# Altium schematic sheet SolarTracker.SchDoc
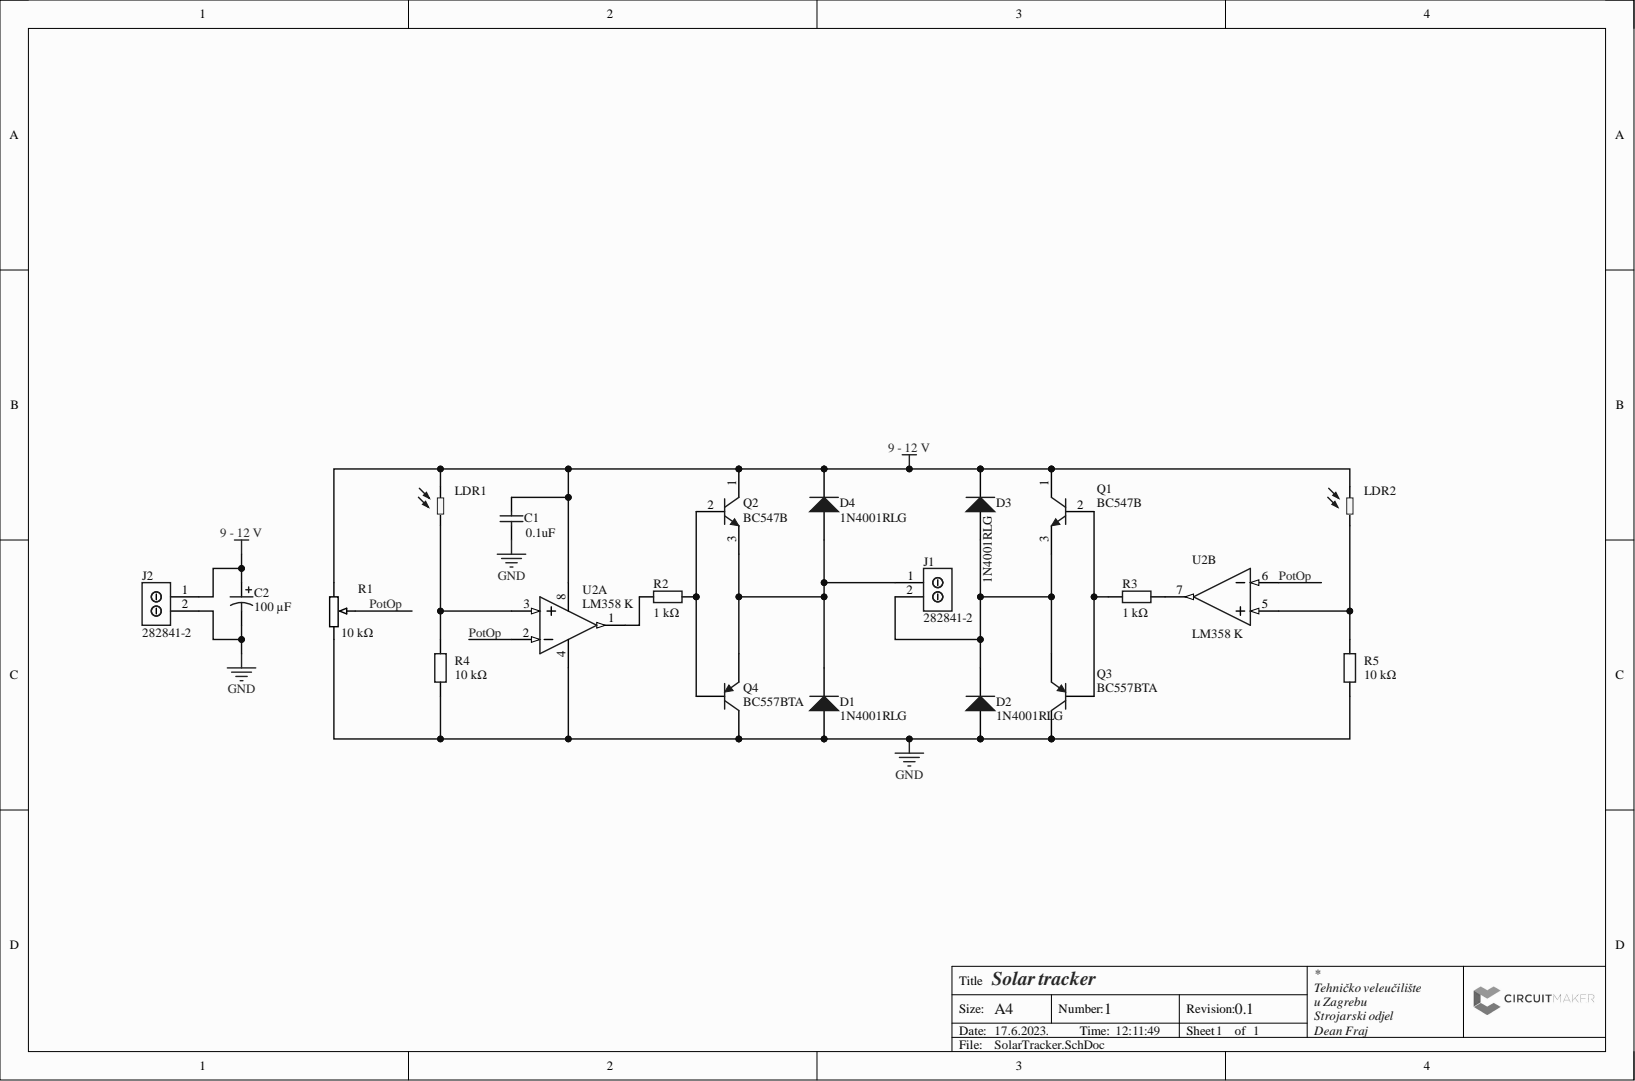
[diagram p0: SolarTracker.SchDoc]

## Parts
C1  value 0.1uF  [PCB-5q3b1ntq00bqadu474q8-1]  (hidden comment: Kemet C315C103M5R5TA)
C2  Capacitance: 100 µF  [PCB-i9faancde6oziqb23cfe-1]  (hidden comment: K50-35)
D1  1N4001RLG  [PCB-z2ypi7zr74mzpyjelpsh-1]
D2  1N4001RLG  [PCB-z2ypi7zr74mzpyjelpsh-1]
D3  1N4001RLG  [PCB-z2ypi7zr74mzpyjelpsh-1]
D4  1N4001RLG  [PCB-z2ypi7zr74mzpyjelpsh-1]
J1  282841-2  [PCB-ubx9hfa8u75k59449c6l-1]
J2  282841-2  [PCB-ubx9hfa8u75k59449c6l-1]
LDR1  (no value)  [PR-2.8-4.2X4.9MM]
LDR2  (no value)  [PR-2.8-4.2X4.9MM]
Q1  BC547B  [ONSC-TO-92-3-29-11]
Q2  BC547B  [ONSC-TO-92-3-29-11]
Q3  BC557BTA  [PCB-bfblt0ig5mu8pnjqgl0u-1]
Q4  BC557BTA  [PCB-bfblt0ig5mu8pnjqgl0u-1]
R1  value 10 kΩ  [PCB-olq4wtbhaxoz7qxl8psv-1]  (hidden comment: Bourns PTV09A-4020F-B103)
R2  Resistance: 1 kΩ  [PCB-set4piecw3gbgqce32cc-1]  (hidden comment: Panasonic ERA6ARW102V)
R3  Resistance: 1 kΩ  [PCB-set4piecw3gbgqce32cc-1]  (hidden comment: Panasonic ERA6ARW102V)
R4  KOA Speer RK73H2ATTD1002F  [RESC2013X60X30ML20T20]  (value not drawn)
R5  KOA Speer RK73H2ATTD1002F  [RESC2013X60X30ML20T20]  (value not drawn)
U2  LM358 K  [PCB-sh3andz6vjobp3klq69x-1]  (parts A,B)

## Nets
9 - 12 V: C1.2 C2.1 D3.2 D4.2 J2.1 LDR1.1 LDR2.1 Q1.1 Q2.1 R1.1 U2.8
GND: C1.1 C2.2 D1.1 D2.1 J2.2 Q3.1 Q4.1 R1.3 R4.2 R5.2 U2.4
PotOp: R1.2 U2.2 U2.6
NetD1_2: D1.2 D4.1 J1.1 Q2.3 Q4.3
NetD2_2: D2.2 D3.1 J1.2 Q1.3 Q3.3
NetLDR1_2: LDR1.2 R4.1 U2.3
NetLDR2_2: LDR2.2 R5.1 U2.5
NetQ1_2: Q1.2 Q3.2 R3.1
NetQ2_2: Q2.2 Q4.2 R2.2
NetR2_1: R2.1 U2.1
NetR3_2: R3.2 U2.7

## Library data of the parts
C1 = CMP-f9eb30fd1b76262c-1: Capacitor, Ceramic, 10000pF, 50VDC, 20-% Tol, 20+% Tol, X7R-TC Code, -15, 15%-TC; Component Kind: Standard; Library Name: My Personal Space; Manufacturer Part Number: C315C103M5R5TA; Mounting Style: Through Hole; Octopart URL: http://octopart.com/c315c103m5r5ta-kemet-1886203; OctopartID: f9eb30fd1b76262c; RoHS: Compliant
C2 = CMP-92395a120dde4dfe-8: ee; Manufacturer Part Number: EEU-FR1E101B; Capacitance Tolerance: ±20%; Lead-Free Status: Lead Free; Voltage Rating (DC): 16 V; Component Kind: Standard; Lead Spacing: 2.5 mm; Library Name: My Personal Space; Lifecycle Status: Not Listed by Manufacturer; Octopart URL: http://octopart.com/eeu-fr1e101b-panasonic-18987649; OctopartID: 92395a120dde4dfe; Packaging: Tape & Reel (TR); REACH SVHC Compliance: No SVHC; RoHS: Compliant; Size-Diameter: 14 mm; Size-Height: 24 mm
D1-D4 = CMP-816519-3: Standard Recovery Rectifier, 2-Pin Axial_Lead, Pb-Free, Tape and Reel; Current Rating: 1.0 A; Lead-Free Status: Lead Free; Voltage Rating (DC): 50.0 V; Case/Package: DO-41; Component Kind: Standard; Halogen Free Status: Halogen Free; Library Name: My Personal Space; Lifecycle Status: Active; Manufacturer Part Number: 1N4001RLG; Mounting Style: Axial; Number of Pins: 2; Octopart Url: https://octopart.com/1n4001rlg-on+semiconductor-322109; OctopartID: 8cde45d043855319; Packaging: Cut Tape (CT); Polarity: Standard; REACH SVHC Compliance: No SVHC; RoHS: Compliant
J1 J2 = CMP-99475216e8174680-24: Conn Terminal Block 2 POS 5.08mm Solder ST Thru-Hole 17.5A/Contact; ELV Compliant: Compliant; Lead-Free Status: Lead Free; Number of Positions: 2; Wire Gauge: 12.0 AWG to 30.0 AWG; Color: Green; Component Kind: Standard; Contact Material: Brass; Contact Plating: Tin; Flammability Rating: UL 94 V-0; Housing Color: Green; Housing Material: Nylon 6/6; Library Name: CommunityVault; Manufacturer Part Number: 282841-2; Mounting Style: Through Hole, Screw; Number of Rows: 1; Octopart URL: https://octopart.com/282841-2-te+connectivity-39539766; OctopartID: 99475216e8174680; Operating Temperature: -40.0 °C to 110 °C; Packaging: Bulk; Pin Pitch: 5.08 mm; RoHS: Compliant; Size-Height: 15.2 mm; Size-Length: 10.2 mm; Size-Width: 9.00 mm
LDR1 LDR2 = CMP-1025123-11: Ldr, 0.5mohm, 80mw, Series Vt900; Manufacturer Part Number: VT90N2; Power Rating: 0.08 W; Voltage Rating (DC): 100.0 V; Component Kind: Standard; Library Name: CommunityVault; Number of Pins: 2; Octopart Url: http://octopart.com/vt90n2-excelitas+technology-19909290; OctopartID: cc400465238c1387; REACH SVHC Compliance: No SVHC; RoHS: Non-Compliant; Seller Landing  Url: https://octopart-clicks.com/click/track?country=US&ak=8157f166&sig=059ea50&sid=2402&ppid=19909290&vpid=36853511&ct=offers
Q1 Q2 = CMP-856192-10: Amplifier Transistor, NPN Silicon, 3-Pin TO-92, Bulk Box; Component Kind: Standard; Library Name: CommunityVault
Q3 Q4 = CMP-a1ea68e5e3127e2d-2: Trans GP BJT PNP 45V 0.1A 3-Pin TO-92 Ammo; Current Rating: -100 mA; Lead-Free Status: Lead Free; Voltage Rating (DC): -45.0 V; Case/Package: TO-92; Component Kind: Standard; Library Name: CommunityVault; Lifecycle Status: Active; Manufacturer Part Number: BC557BTA; Mounting Style: Through Hole; Number of Pins: 3; Octopart URL: http://octopart.com/bc557bta-fairchild+semiconductor-354206; OctopartID: a1ea68e5e3127e2d; Packaging: Tape; Polarity: PNP; REACH SVHC Compliance: No SVHC; RoHS: Compliant
R1 = CMP-899a408935a4565d-10: Linear Potentiometer w/ 6 mm Dia. Shaft; 10kOhm; +/-20%; 0.05W; Thru Hole; Power Rating: 50.0 mW; Resistance Tolerance: ±20%; Voltage Rating (DC): 20.0 V; Component Kind: Standard; Library Name: My Personal Space; Manufacturer Part Number: PTV09A-4020F-B103; Mounting Style: Through Hole, Bracket; Octopart URL: https://octopart.com/ptv09a-4020f-b103-bourns-1677656; OctopartID: 899a408935a4565d; Packaging: Bulk; REACH SVHC Compliance: No SVHC; RoHS: Compliant
R2 R3 = CMP-778abdcdd74ba84d-4: Res Thin Film 0805 1K Ohm 0.05% 1/8W ±10ppm/°C Molded SMD SMD Punched Carrier T/R; Case/Package (SI): 2012; Lead-Free Status: Lead Free; Power Rating: 125 mW; Voltage Rating (DC): 100 V; Case/Package: 0805; Component Kind: Standard; Library Name: My Personal Space; Lifecycle Status: Not Listed by Manufacturer; Manufacturer Part Number: ERA6ARW102V; Mounting Style: Surface Mount; Number of Pins: 2; Octopart URL: http://octopart.com/era6arw102v-panasonic-55412135; OctopartID: 778abdcdd74ba84d; Packaging: Cut Tape (CT); Resistance Tolerance: ±0.05%; RoHS: Compliant
R4 R5 = CMP-2823447-3: Res Thick Film 0805 10K Ohm 1% 0.125W(1/8W) ±100ppm/C Molded SMD Automotive Punched Paper T/R; Case/Package (SI): 2012; Lead-Free Status: Lead Free; Resistance: 10 kΩ; Temperature Coefficient: 100.0 ppm/°C; Voltage Rating (DC): 150.0 V; Case/Package: 0805; Component Kind: Standard; Library Name: My Personal Space; Manufacturer Part Number: RK73H2ATTD1002F; Mounting Style: Surface Mount; Octopart Url: https://octopart.com/rk73h2attd1002f-koa+speer-2167241; OctopartID: 600ea92554a1bfc9; Power Rating: 0.125 W; Resistance Tolerance: ±1%; RoHS: Compliant; Size-Length: 0.002 m; Size-Width: 0.00125 m
U2 = CMP-83e04aece58f5c27-14: SERVAL LM358; Manufacturer: Texas Instrument; Manufacturer Part Number: LM358AP; Input Offset Voltage (Max): 9 mV; Input Voltage (Dual): ±1.5 V ~ ±16 V; Input Voltage (Single): 3 V ~ 32 V; Number of Elements: 2; Output Current: 20 mA; Component Kind: Standard; Library Name: My Personal Space; Number of Pins: 8; Package: DIP
